# Altium schematic sheet [8] USB.SchDoc("[8] USB")
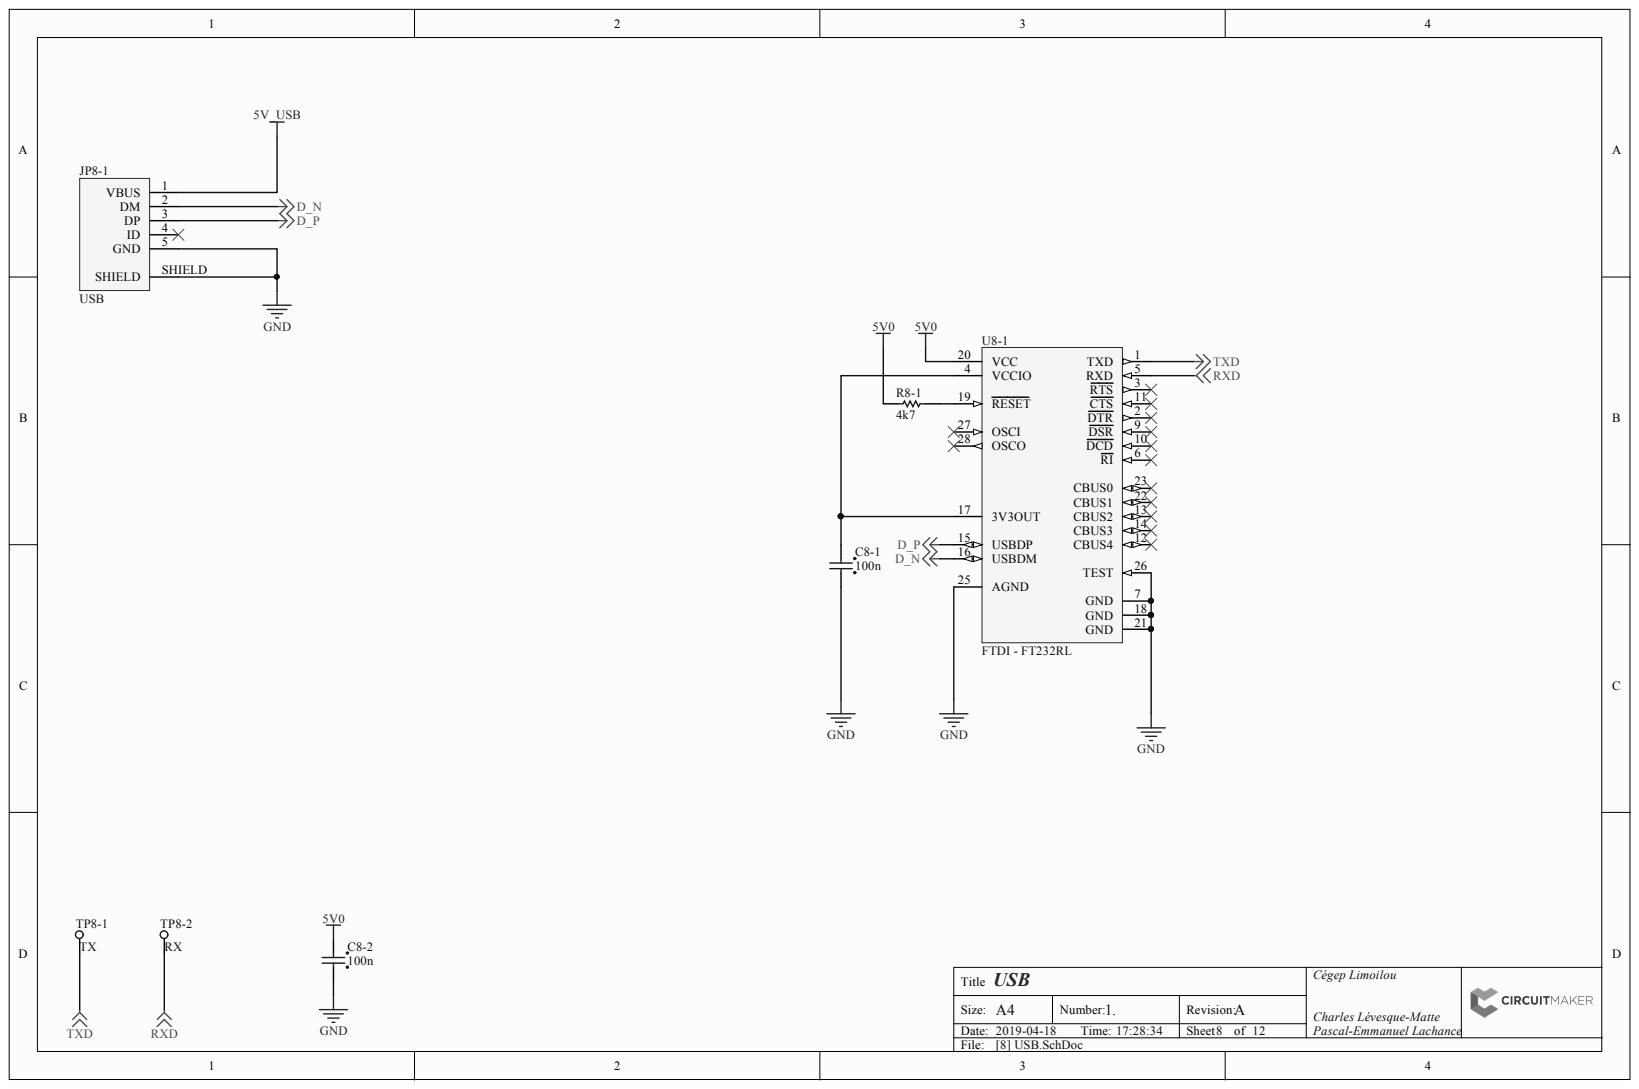
[diagram p0: [8] USB.SchDoc]

## Parts
C8-1  100n  [CAPC1608X90X35ML15T15]
C8-2  100n  [CAPC1608X90X35ML15T15]
JP8-1  USB  [PCB-microUSB]
R8-1  4k7  [PCB-g8ucq7vw1s2sdhfr4zem-1]
TP8-1  TX  [PCB-a7rpxwhzqdkz91v608la-1]
TP8-2  RX  [PCB-a7rpxwhzqdkz91v608la-1]
U8-1  FTDI - FT232RL  Manufacturer Part Number: FT232RL  [SOP65P780X200-28N]

## Nets
5V0: C8-2.2 R8-1.1 U8-1.20(VCC)
5V_USB: JP8-1.1(VBUS)
D_N: JP8-1.2(DM) U8-1.16(USBDM)
D_P: JP8-1.3(DP) U8-1.15(USBDP)
GND: C8-1.1 C8-2.1 JP8-1.5(GND) JP8-1.SHIELD U8-1.7(GND) U8-1.18(GND) U8-1.21(GND) U8-1.25(AGND) U8-1.26(TEST)
RXD: TP8-2.1 U8-1.5(RXD)
TXD: TP8-1.1 U8-1.1(TXD)
NetC8-1_2: C8-1.2 U8-1.4(VCCIO) U8-1.17(3V3OUT)
NetR8-1_2: R8-1.2 U8-1.19(RESET)

Not connected: JP8-1 4(ID); U8-1 2(DTR) 3(RTS) 6(RI) 9(DSR) 10(DCD) 11(CTS) 12(CBUS4) 13(CBUS2) 14(CBUS3) 22(CBUS1) 23(CBUS0) 27(OSCI) 28(OSCO)

## Library data of the parts
C8-1 C8-2 = CMP-1af8d38ac64bc53f-2: CL10 Series 0.1 uF 50 V ±10% Tolerance X7R SMT Multilayer Ceramic Capacitor; Capacitance: 100 nF; Capacitance Tolerance: ±10%; Component Kind: Standard; Library Name: CommunityVault; Mounting Style: Surface Mount; OctopartID: 1af8d38ac64bc53f; Octopart URL: http://octopart.com/cl10b104kb8nnnc-samsung-7928277; RoHS: Compliant
JP8-1 = CMP-943259-24: Micro-USB B Receptacle, Bottom Mount, SMT, with Solder Tabs, Lead-Free; Manufacturer Part Number: 1050170001; Current Rating: 2.50 A; Gender: Female; Number of Circuits: 5; Component Kind: Standard; Contact Material: Copper Alloy; Contact Plating: Gold; Halogen Free Status: Low Halogen; Library Name: CommunityVault; Mounting Style: Horizontal, Surface Mount, PCB; Number of Contacts: 5; OctopartID: 5e94972b6a858153; Octopart URL: https://octopart.com/1050170001-molex-11866487; REACH SVHC Compliance: No SVHC; RoHS: Compliant
R8-1 = CMP-011ec9310fadded9-4: Res Thick Film 0603 4.7K Ohm 1% 0.1W(1/10W) ±100ppm/C Pad SMD Automotive T/R; Manufacturer Part Number: RMCF0603FT4K70; Lead-Free Status: Lead Free; Power Rating: 100 mW; Resistance: 4.70 kΩ; Case/Package: 0603; Component Kind: Standard; Library Name: CommunityVault; Mounting Style: Surface Mount; OctopartID: 011ec9310fadded9; Octopart URL: https://octopart.com/rmcf0603ft4k70-stackpole+electronics-19213269; Packaging: Tape & Reel (TR); Resistance Tolerance: ±1%; RoHS: Compliant
TP8-1 TP8-2 = CMP-5j384tb079h9dvgbp04a-2: Through-hole Testpoint; Component Kind: Standard; Library Name: CommunityVault
U8-1 = CMP-1159378-16: SMD IC Interface; USB to UART; Speed 300b-3Mbaud; VCC 1.8V-5.25V; Data Rate: 3.00 Mbps; Lead-Free Status: Lead Free; Supply Current: 15.0 mA; Supply Voltage (DC): 1.80 V (min); Component Kind: Standard; Library Name: CommunityVault; Mounting Style: Surface Mount; Number of Pins: 28; OctopartID: bcc675ec4d616301; Octopart URL: http://octopart.com/ft232rl-ftdi-2151617; Packaging: Tube; REACH SVHC Compliance: No SVHC; RoHS: Compliant
